# Altium schematic sheet Probe_Pipe_Mapping_V1.0.0.SchDoc
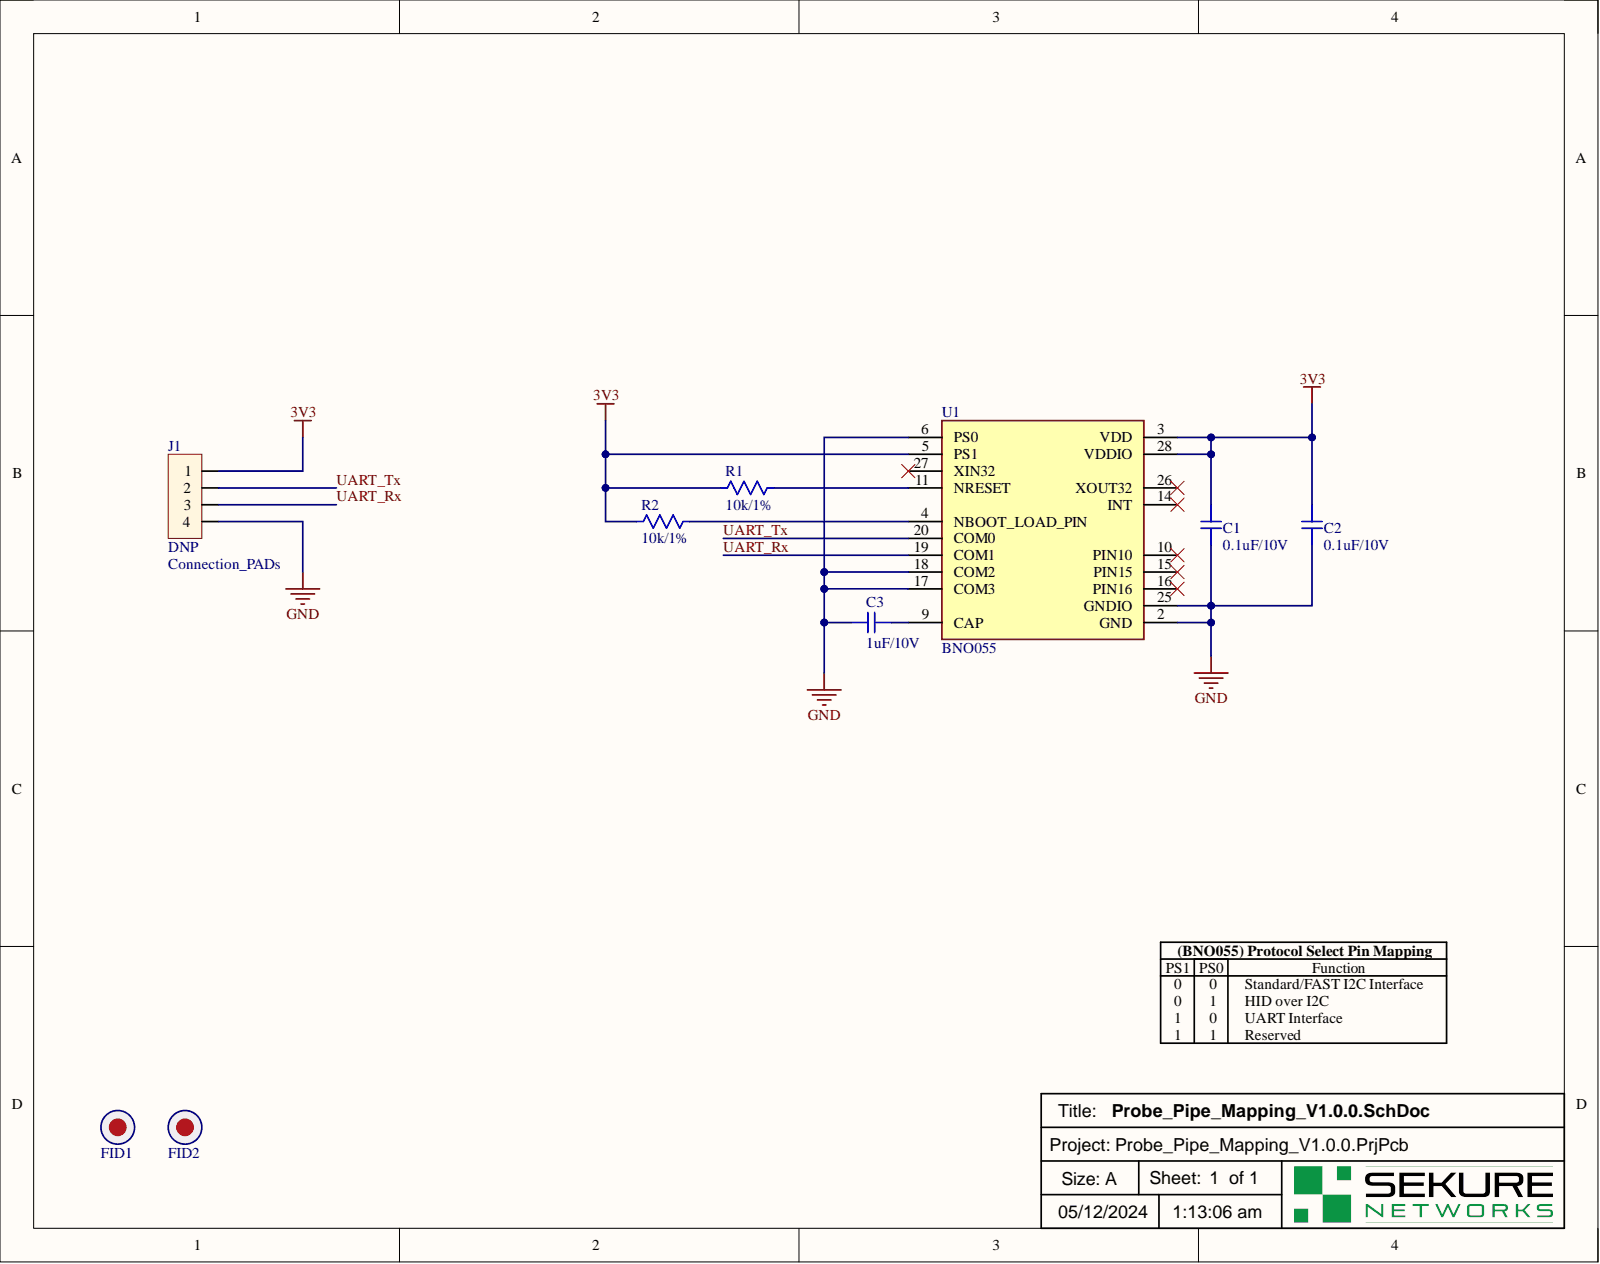
[diagram p0: Probe_Pipe_Mapping_V1.0.0.SchDoc]

## Parts
C1  value 0.1uF/10V  [CAP 0402]  (hidden comment: Ceramic Capacitor)
C2  value 0.1uF/10V  [CAP 0402]  (hidden comment: Ceramic Capacitor)
C3  value 1uF/10V  [CAP 0402]  (hidden comment: Ceramic Capacitor)
FID1  Fiducal marks  [Fiducial mark]  (value not drawn)  (no BOM)
FID2  Fiducal marks  [Fiducial mark]  (value not drawn)  (no BOM)
J1  value Connection_PADs  Assembly: DNP  [Conn_Pad Small]  (hidden comment: Connector)
R1  value 10k/1%  [RES 0402]  (hidden comment: Resistor)
R2  value 10k/1%  [RES 0402]  (hidden comment: Resistor)
U1  value BNO055  [LGA28R50P4X10_380X520X100]  (hidden comment: Sensor)

## Nets
3V3: C1.2 C2.2 J1.1 R1.1 R2.1 U1.3(VDD) U1.5(PS1) U1.28(VDDIO)
GND: C1.1 C2.1 C3.1 J1.4 U1.2(GND) U1.6(PS0) U1.17(COM3) U1.18(COM2) U1.25(GNDIO)
UART_Rx: J1.3 U1.19(COM1)
UART_Tx: J1.2 U1.20(COM0)
NetC3_2: C3.2 U1.9(CAP)
NetR1_2: R1.2 U1.11(NRESET)
NetR2_2: R2.2 U1.4(NBOOT_LOAD_PIN)

Not connected: FID1 0; FID2 0; U1 10(PIN10) 14(INT) 15(PIN15) 16(PIN16) 26(XOUT32) 27(XIN32)

## Library data of the parts
C1 C2 = CL05A104MP5NNNC: Ceramic Capacitor, 0.1 µF, 10 V, 0402 [1005 Metric], ± 20%, X5R, CL Series; Manufacturer: Samsung; Capacitance: 100nF; Voltage Rating: 10V; Case Code (Imperial): 0402; Case Code (Metric): 1005; Case/Package: 0402; Category: Ceramic Capacitors; Component Kind: Standard; ComponentLink1Description: https://datasheet.ciiva.com/25709/cl05a104mp5nnnc-25709105.pdf?src-supplier=Digi-Key; ComponentLink1URL: https://datasheet.ciiva.com/25709/cl05a104mp5nnnc-25709105.pdf?src-supplier=Digi-Key; Construction: Flat; Depth: 0.5mm; Dielectric: X5R; DigiKey: 1276-1443-1-ND; Height: 0.5mm; Lead Free: Lead Free; Length: 1mm; Library Name: Ceramic Capacitors.IntLib; Max Operating Temperature: 85°C; Min Operating Temperature: -55°C; Mount: Surface Mount; P/N: CL05A104MP5NNNC; Packaging: Tape and Reel; Pricing 1: 1=0.10000, 10=0.01700, 50=0.00940, 100=0.00770, 500=0.00552, 1000=0.00434, 2500=0.00395, 5000=0.00363 (USD); Radiation Hardening: No; RoHS: Yes; RoHS Compliant: Yes; Series: CL; Stock 1: 1217160; Supplier 1: Digi-Key; Thickness: 0.022inch; Tolerance: 20%; Voltage Rating (DC): 10V; Width: 0.02inch
C3 = CL05A105MP5NNNC: CAP CER 1UF 10V X5R 0402; Manufacturer: Samsung; Tolerance: 20%; Capacitance: 1uF; Case Code (Imperial): 0402; Case Code (Metric): 1005; Case/Package: 0402; Category: Ceramic Capacitors; Component Kind: Standard; ComponentLink1Description: https://datasheet.ciiva.com/25802/cl05a105mp5nnnc-25802049.pdf?src-supplier=Digi-Key; ComponentLink1URL: https://datasheet.ciiva.com/25802/cl05a105mp5nnnc-25802049.pdf?src-supplier=Digi-Key; Construction: Flat; Depth: 0.5mm; Dielectric: X5R; DigiKey: 1276-1448-1-ND; Height: 0.5mm; Lead Free: Lead Free; Length: 1mm; Library Name: Ceramic Capacitors.IntLib; Max Operating Temperature: 85°C; Min Operating Temperature: -55°C; Mount: Surface Mount; P/N: CL05A105MP5NNNC; Package Quantity: 1; Packaging: Tape and Reel; Pricing 1: 1=0.10000 (USD); Radiation Hardening: No; RoHS: Yes; RoHS Compliant: Yes; Series: CL; Stock 1: 2439; Supplier 1: Digi-Key; Thickness: 0.022inch; Voltage Rating: 10V; Voltage Rating (DC): 10V; Width: 0.02inch
FID1 FID2 = Fiducial mark: Fiducial mark mini  in top placement.; Number: 1; Library Name: Connectors.IntLib
J1 = Conc_Pad_Small: 4 PCB SMD PADs for Connection; Component Kind: Standard; ComponentLink1Description: https://datasheet.ciiva.com/5741/1605505-5741440.pdf?src-supplier=Farnell; ComponentLink1URL: https://datasheet.ciiva.com/5741/1605505-5741440.pdf?src-supplier=Farnell; ComponentLink2Description: https://datasheet.ciiva.com/30254/eng-cs-1308389-eurostyle-terminal-blocks-0607-30254374.pdf?src-supplier=Onlinecomponents.com; ComponentLink2URL: https://datasheet.ciiva.com/30254/eng-cs-1308389-eurostyle-terminal-blocks-0607-30254374.pdf?src-supplier=Onlinecomponents.com; ComponentLink3Description: https://datasheet.ciiva.com/3141/eng-cs-1308389-eurostyle-terminal-blocks-0607-3141117.pdf?src-supplier=Avnet; ComponentLink3URL: https://datasheet.ciiva.com/3141/eng-cs-1308389-eurostyle-terminal-blocks-0607-3141117.pdf?src-supplier=Avnet; ComponentLink4Description: https://datasheet.ciiva.com/14609/70087596-14609846.pdf?src-supplier=Allied+Electronics; ComponentLink4URL: https://datasheet.ciiva.com/14609/70087596-14609846.pdf?src-supplier=Allied+Electronics; ComponentLink5Description: https://datasheet.ciiva.com/823/eng-cd-282834-c1-823474.pdf?src-supplier=Digikey; ComponentLink5URL: https://datasheet.ciiva.com/823/eng-cd-282834-c1-823474.pdf?src-supplier=Digikey; Library Name: Connectors.IntLib
R1 R2 = RK73H1ETTPD1002F: RES SMD 10K OHM 1% 1/10W 0402; Manufacturer: KOA Speer; Power Rating: 62.5mW; Resistance: 10kR; Temperature Coefficient: 100ppm/°C; Voltage Rating (DC): 50V; Case/Package: 0402; Component Kind: Standard; DigiKey: 2019-RK73H1ETTPD1002FCT-ND; Length: 1mm; Library Name: Resistors.IntLib; P/N: RK73H1ETTPD1002F; Pricing 1: 1=0.10000, 10=0.02500, 100=0.01020, 1000=0.00457, 2500=0.00397, 5000=0.00328 (USD); RoHS: Yes; Stock 1: 19968; Supplier 1: Digi-Key; Width: 500um
U1 = BNO055: BNO055 Series 1.7 to 3.6 V Intelligent 9-Axis Absolute Orientation Sensor-LGA-28; Manufacturer: Bosch Tools; Category: Linear ICs; Component Kind: Standard; DigiKey: 828-1058-1-ND; Library Name: Sensors.IntLib; P/N: BNO055; Pricing: 1=16.15, 5=13.616, 10=12.652, 25=11.4832, 50=10.6718, 100=9.9183, 500=8.36848, 1000=7.925 (USD); RoHS: No; Stock: 6369; Supplier: Digikey
